# Altium schematic sheet EVK-NORA-W4_SCHEM_0004_Debug_Memory.SchDoc
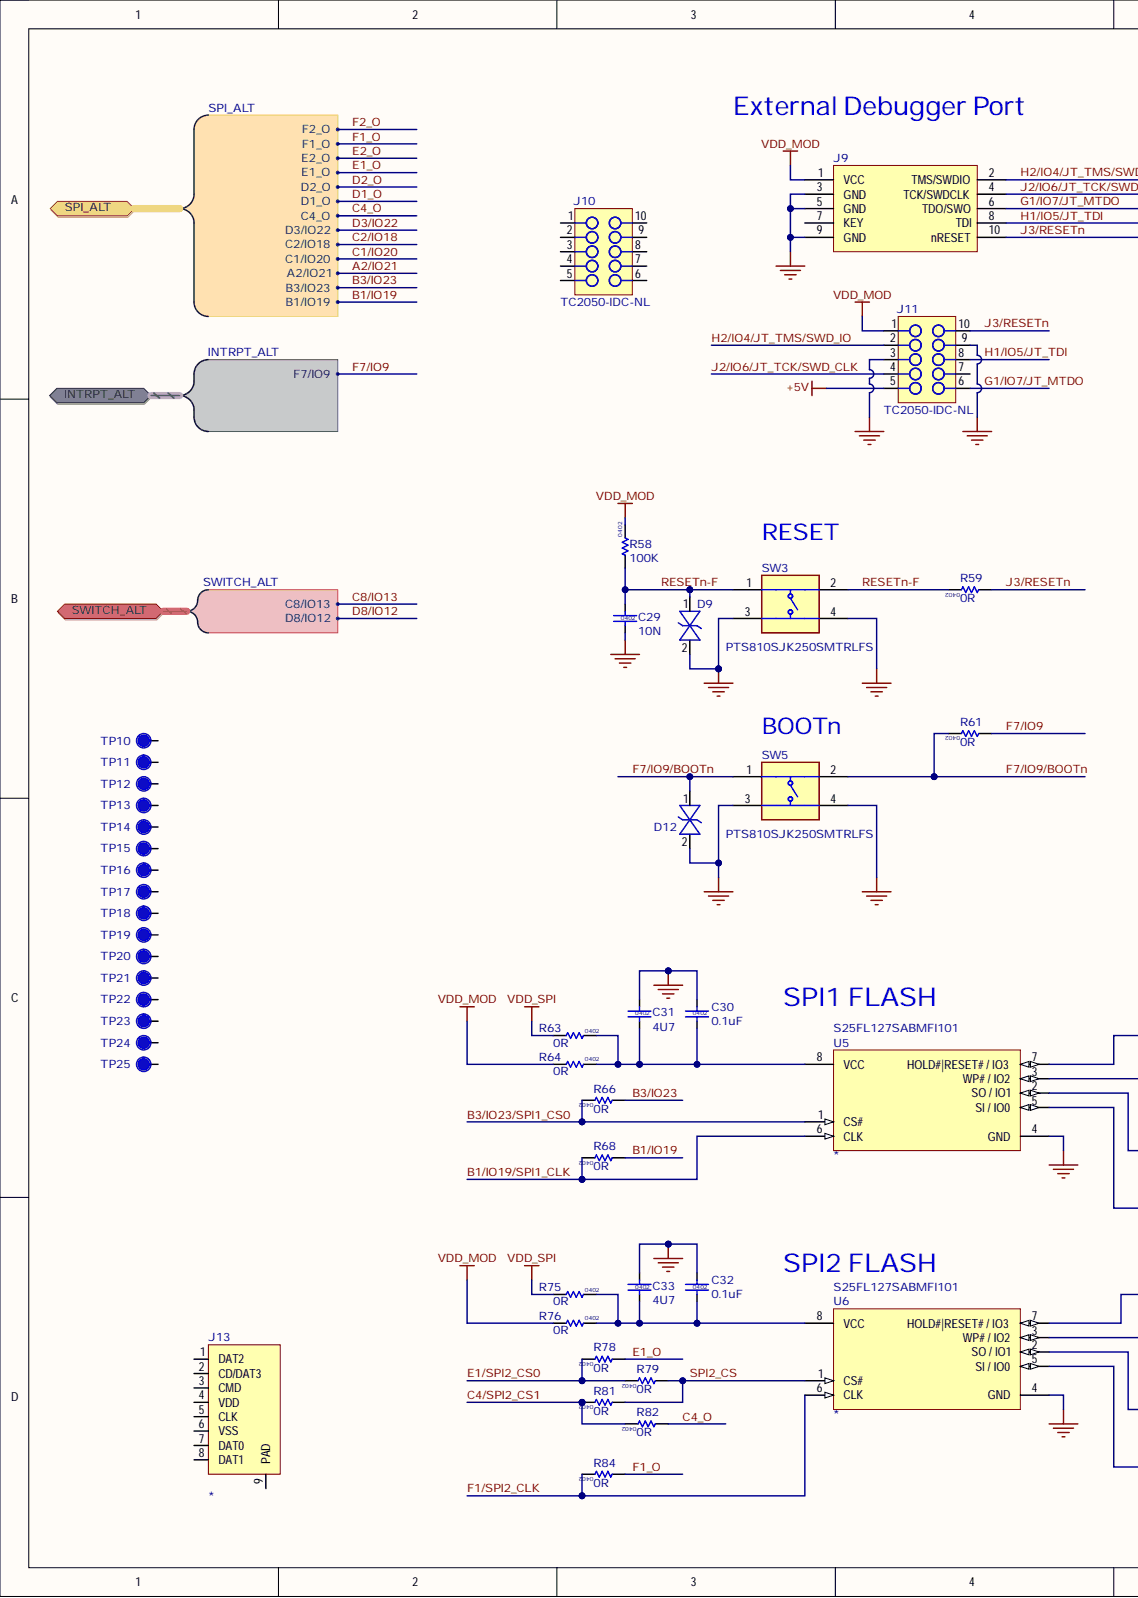
[diagram p0: EVK-NORA-W4_SCHEM_0004_Debug_Memory.SchDoc, left]
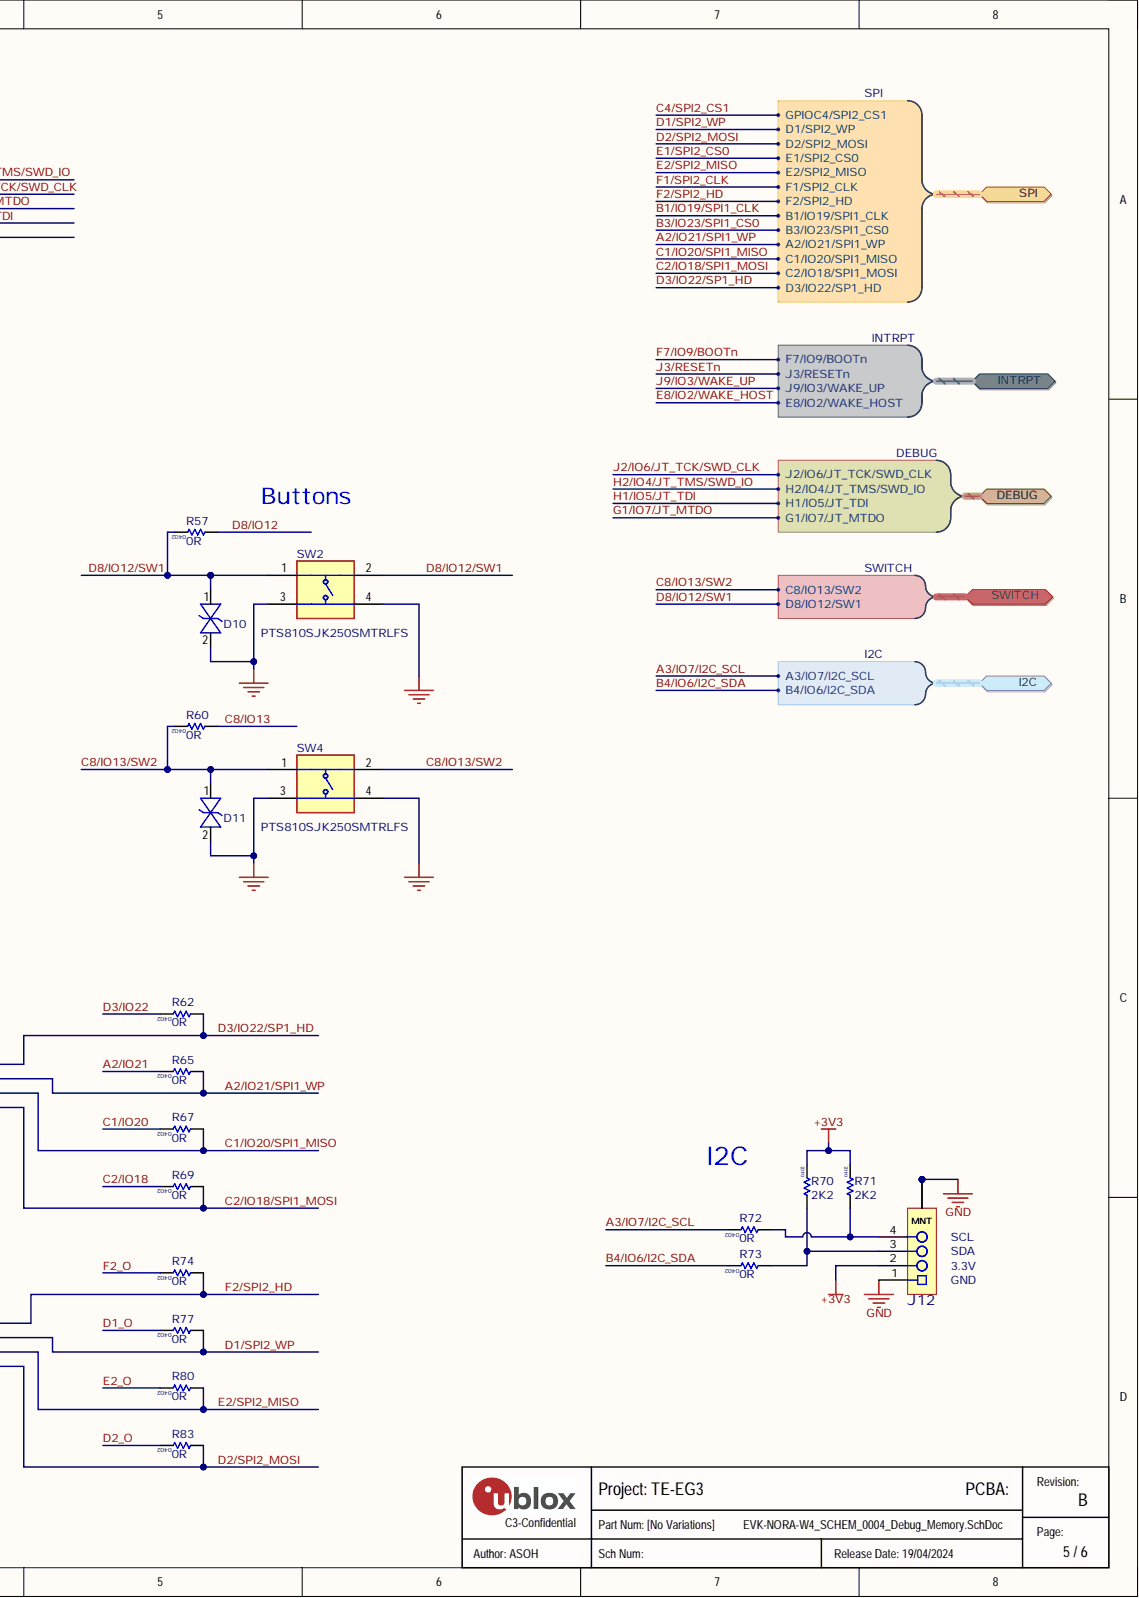
[diagram p1: EVK-NORA-W4_SCHEM_0004_Debug_Memory.SchDoc, right]

## Parts
C29  value 10N  Size: 0402  [Cap_0402_1100Lx600Wx600H_600x600Pad_350G_FLX]  (hidden comment: UBXH01-002302510N)
C30  value 0.1uF  Size: 0402  [Cap 0402 HD]  (hidden comment: 120-000160.1uF)
C31  value 4U7  Size: 0402  [Cap 0402 HD]  (hidden comment: UBXH01-00002434U7)
C32  value 0.1uF  Size: 0402  [Cap 0402 HD]  (hidden comment: 120-000160.1uF)
C33  value 4U7  Size: 0402  [Cap 0402 HD]  (hidden comment: UBXH01-00002434U7)
D9  110-00019  [SOD-923]  (value not drawn)
D10  110-00019  [SOD-923]  (value not drawn)
D11  110-00019  [SOD-923]  (value not drawn)
D12  110-00019  [SOD-923]  (value not drawn)
J9  130-00099  [HDR.050P-10Pin-2x5-SMT_Key]  (value not drawn)
J10  TC2050-IDC-NLDNP  [TC2050-IDC-NL]  (value not drawn)  (no BOM)
J11  TC2050-IDC-NLDNP  [TC2050-IDC-NL]  (value not drawn)  (no BOM)
J12  UBXH04-0000797  [SM04B-SRSS-TB]  (value not drawn)
J13  130-00062  [Micro_SD_SKT_SMT_RA]  (value not drawn)
R57  value 0R  Size: 0402  [RES 0402 HD]  (hidden comment: UBXH18-00030020R)
R58  value 100K  Size: 0402  [RES_0402_1100Lx600Wx400H_500x600Pad_400G_FLX]  (hidden comment: UBXH18-0008097100K)
R59  value 0R  Size: 0402  [RES 0402 HD]  (hidden comment: UBXH18-00030020R)
R60  value 0R  Size: 0402  [RES 0402 HD]  (hidden comment: UBXH18-00030020R)
R61  value 0R  Size: 0402  [RES 0402 HD]  (hidden comment: UBXH18-00030020R)
R62  value 0R  Size: 0402  [RES 0402 HD]  (hidden comment: UBXH18-00030020R)
R63  value 0R  Size: 0402  [RES 0402 HD]  (hidden comment: UBXH18-00030020R)
R64  value 0R  Size: 0402  [RES 0402 HD]  (hidden comment: UBXH18-00030020R)
R65  value 0R  Size: 0402  [RES 0402 HD]  (hidden comment: UBXH18-00030020R)
R66  value 0R  Size: 0402  [RES 0402 HD]  (hidden comment: UBXH18-00030020R)
R67  value 0R  Size: 0402  [RES 0402 HD]  (hidden comment: UBXH18-00030020R)
R68  value 0R  Size: 0402  [RES 0402 HD]  (hidden comment: UBXH18-00030020R)
R69  value 0R  Size: 0402  [RES 0402 HD]  (hidden comment: UBXH18-00030020R)
R70  value 2K2  Size: 0402  [RES_0402_1100Lx600Wx400H_500x600Pad_400G_FLX]  (hidden comment: UBXH18-00080572K2)
R71  value 2K2  Size: 0402  [RES_0402_1100Lx600Wx400H_500x600Pad_400G_FLX]  (hidden comment: UBXH18-00080572K2)
R72  value 0R  Size: 0402  [RES 0402 HD]  (hidden comment: UBXH18-00030020R)
R73  value 0R  Size: 0402  [RES 0402 HD]  (hidden comment: UBXH18-00030020R)
R74  value 0R  Size: 0402  [RES 0402 HD]  (hidden comment: UBXH18-00030020R)
R75  value 0R  Size: 0402  [RES 0402 HD]  (hidden comment: UBXH18-00030020R)
R76  value 0R  Size: 0402  [RES 0402 HD]  (hidden comment: UBXH18-00030020R)
R77  value 0R  Size: 0402  [RES 0402 HD]  (hidden comment: UBXH18-00030020R)
R78  value 0R  Size: 0402  [RES 0402 HD]  (hidden comment: UBXH18-00030020R)
R79  value 0R  Size: 0402  [RES 0402 HD]  (hidden comment: UBXH18-00030020R)
R80  value 0R  Size: 0402  [RES 0402 HD]  (hidden comment: UBXH18-00030020R)
R81  value 0R  Size: 0402  [RES 0402 HD]  (hidden comment: UBXH18-00030020R)
R82  value 0R  Size: 0402  [RES 0402 HD]  (hidden comment: UBXH18-00030020R)
R83  value 0R  Size: 0402  [RES 0402 HD]  (hidden comment: UBXH18-00030020R)
R84  value 0R  Size: 0402  [RES 0402 HD]  (hidden comment: UBXH18-00030020R)
SW2  PTS810SJK250SMTRLFS  [PTS810SJG250SMTRLFS]
SW3  PTS810SJK250SMTRLFS  [PTS810SJG250SMTRLFS]
SW4  PTS810SJK250SMTRLFS  [PTS810SJG250SMTRLFS]
SW5  PTS810SJK250SMTRLFS  [PTS810SJG250SMTRLFS]
TP10  TP  [TP10R]  (no BOM)
TP11  TP  [TP10R]  (no BOM)
TP12  TP  [TP10R]  (no BOM)
TP13  TP  [TP10R]  (no BOM)
TP14  TP  [TP10R]  (no BOM)
TP15  TP  [TP10R]  (no BOM)
TP16  TP  [TP10R]  (no BOM)
TP17  TP  [TP10R]  (no BOM)
TP18  TP  [TP10R]  (no BOM)
TP19  TP  [TP10R]  (no BOM)
TP20  TP  [TP10R]  (no BOM)
TP21  TP  [TP10R]  (no BOM)
TP22  TP  [TP10R]  (no BOM)
TP23  TP  [TP10R]  (no BOM)
TP24  TP  [TP10R]  (no BOM)
TP25  TP  [TP10R]  (no BOM)
U5  Manufacturer Part Number 1: S25FL127SABMFI101  [SOIC-8W]  (hidden comment: 240-00017)
U6  Manufacturer Part Number 1: S25FL127SABMFI101  [SOIC-8W]  (hidden comment: 240-00017)

## Ports
DEBUG, DEBUG.G1/IO7/JT_MTDO, DEBUG.H1/IO5/JT_TDI, DEBUG.H2/IO4/JT_TMS/SWD_IO, DEBUG.J2/IO6/JT_TCK/SWD_CLK, I2C, I2C.A3/IO7/I2C_SCL, I2C.B4/IO6/I2C_SDA, INTRPT, INTRPT.E8/IO2/WAKE_HOST, INTRPT.F7/IO9/BOOTn, INTRPT.J3/RESETn, INTRPT.J9/IO3/WAKE_UP, INTRPT_ALT, INTRPT_ALT.F7/IO9, SPI, SPI.A2/IO21/SPI1_WP, SPI.B1/IO19/SPI1_CLK, SPI.B3/IO23/SPI1_CS0, SPI.C1/IO20/SPI1_MISO, SPI.C2/IO18/SPI1_MOSI, SPI.D1/SPI2_WP, SPI.D2/SPI2_MOSI, SPI.D3/IO22/SP1_HD, SPI.E1/SPI2_CS0, SPI.E2/SPI2_MISO, SPI.F1/SPI2_CLK, SPI.F2/SPI2_HD, SPI.GPIOC4/SPI2_CS1, SPI_ALT, SPI_ALT.A2/IO21, SPI_ALT.B1/IO19, SPI_ALT.B3/IO23, SPI_ALT.C1/IO20, SPI_ALT.C2/IO18, SPI_ALT.C4_O, SPI_ALT.D1_O, SPI_ALT.D2_O, SPI_ALT.D3/IO22, SPI_ALT.E1_O, SPI_ALT.E2_O, SPI_ALT.F1_O, SPI_ALT.F2_O, SWITCH, SWITCH.C8/IO13/SW2, SWITCH.D8/IO12/SW1, SWITCH_ALT, SWITCH_ALT.C8/IO13, SWITCH_ALT.D8/IO12

## Nets
+3V3: J12.2 R70.2 R71.2
+5V: J11.5
GND: C29.2 C30.1 C31.1 C32.1 C33.1 D9.2 D10.2 D11.2 D12.2 J9.3(GND) J9.5(GND) J9.9(GND) J11.3 J12.1 J12.M1 J12.M2 SW2.3 SW2.4 SW3.3 SW3.4 SW4.3 SW4.4 SW5.3 SW5.4 U5.4(GND) U6.4(GND)
VDD_MOD: J9.1(VCC) J11.1 R58.2 R64.1 R76.1
VDD_SPI: R63.1 R75.1
A2/IO21: R65.2 ; port SPI_ALT
A2/IO21/SPI1_WP: R65.1 U5.3(WP#_/_IO2) ; port SPI
A3/IO7/I2C_SCL: R72.2 ; port I2C
B1/IO19: R68.1 ; port SPI_ALT
B1/IO19/SPI1_CLK: R68.2 U5.6(CLK) ; port SPI
B3/IO23: R66.1 ; port SPI_ALT
B3/IO23/SPI1_CS0: R66.2 U5.1(CS#) ; port SPI
B4/IO6/I2C_SDA: R73.2 ; port I2C
C1/IO20: R67.2 ; port SPI_ALT
C1/IO20/SPI1_MISO: R67.1 U5.2(SO_/_IO1) ; port SPI
C2/IO18: R69.2 ; port SPI_ALT
C2/IO18/SPI1_MOSI: R69.1 U5.5(SI_/_IO0) ; port SPI
C4/SPI2_CS1: R81.2 R82.2 ; port SPI
C4_O: R82.1 ; port SPI_ALT
C8/IO13: R60.1 ; port SWITCH_ALT
C8/IO13/SW2: D11.1 R60.2 SW4.1 SW4.2 ; port SWITCH
D1/SPI2_WP: R77.1 U6.3(WP#_/_IO2) ; port SPI
D1_O: R77.2 ; port SPI_ALT
D2/SPI2_MOSI: R83.1 U6.5(SI_/_IO0) ; port SPI
D2_O: R83.2 ; port SPI_ALT
D3/IO22: R62.2 ; port SPI_ALT
D3/IO22/SP1_HD: R62.1 U5.7(HOLD#|RESET#_/_IO3) ; port SPI
D8/IO12: R57.1 ; port SWITCH_ALT
D8/IO12/SW1: D10.1 R57.2 SW2.1 SW2.2 ; port SWITCH
E1/SPI2_CS0: R78.2 R79.2 ; port SPI
E1_O: R78.1 ; port SPI_ALT
E2/SPI2_MISO: R80.1 U6.2(SO_/_IO1) ; port SPI
E2_O: R80.2 ; port SPI_ALT
F1/SPI2_CLK: R84.2 U6.6(CLK) ; port SPI
F1_O: R84.1 ; port SPI_ALT
F2/SPI2_HD: R74.1 U6.7(HOLD#|RESET#_/_IO3) ; port SPI
F2_O: R74.2 ; port SPI_ALT
F7/IO9: R61.1 ; port INTRPT_ALT
F7/IO9/BOOTn: D12.1 R61.2 SW5.1 SW5.2 ; port INTRPT
G1/IO7/JT_MTDO: J9.6(TDO/SWO) J11.6 ; port DEBUG
H1/IO5/JT_TDI: J9.8(TDI) J11.8 ; port DEBUG
H2/IO4/JT_TMS/SWD_IO: J9.2(TMS/SWDIO) J11.2 ; port DEBUG
J2/IO6/JT_TCK/SWD_CLK: J9.4(TCK/SWDCLK) J11.4 ; port DEBUG
J3/RESETn: J9.10(nRESET) J11.10 R59.1 ; port INTRPT
RESETn-F: C29.1 D9.1 R58.1 R59.2 SW3.1 SW3.2
SPI2_CS: R79.1 R81.1 U6.1(CS#)
NetC30_2: C30.2 C31.2 R63.2 R64.2 U5.8(VCC)
NetC32_2: C32.2 C33.2 R75.2 R76.2 U6.8(VCC)
NetJ12_3: J12.3 R70.1 R73.1
NetJ12_4: J12.4 R71.1 R72.1
E8/IO2/WAKE_HOST: ; port INTRPT
J9/IO3/WAKE_UP: ; port INTRPT

Not connected: J9 7(KEY); J10 1 2 3 4 5 6 7 8 9 10; J11 7 9; J13 1(DAT2) 2(CD/DAT3) 3(CMD) 4(VDD) 5(CLK) 6(VSS) 7(DAT0) 8(DAT1) 9(PAD); TP10 1; TP11 1; TP12 1; TP13 1; TP14 1; TP15 1; TP16 1; TP17 1; TP18 1; TP19 1; TP20 1; TP21 1; TP22 1; TP23 1; TP24 1; TP25 1

## Library data of the parts
C29 = UBXH01-0023025: CAP CER X7R 0402 10N 10% 16V; Manufacturer: Murata Manufacturing Company, Ltd.; Manufacturer P/N: GRM155R71C103KA01; Database Table Name: Passive - Capacitor; Editor: roland.rust; Env: ROHS; Mfg P/N Released: Yes; Mfg P/N Split: 100; Mfg P/N Status: NRND; Perferred: PREF; Permitted Use: Volume Products; Released by: anthony.gergis; Component Kind: Standard; Library Name: UBX_Parts_DB_Lib.DbLib; Manufacturer 2: Samsung Electro-Mechanics; Manufacturer P/N 2: CL05B103KO5NNNC; Max Operating Temp: 125°C; Max Voltage: 16V; Mfg P/N Datasheet 2: https://wiki.u-blox.com/bin/view/Generic/Docs/DocumentSummary?index=28567; Mfg P/N Released 2: Yes; Mfg P/N Split 2: 0; Mfg P/N Status 2: Active; Min Operating Temp: -55°C; Part Number: UBXH01-0023025; Part Type: Capacitor; RefDes: C; Release Data: 2021-02-16T13:14:11; Signal Integrity: Cap Semi; Simulation: CAP; Tolerance: 10%; UBX Wiki: https://wiki.u-blox.com/bin/view/Operations/PartDetails?index=5491
C30 C32 = 120-00016: Cap, Ceramic 0.1uF 10V 10% X5R 0402; Manufacturer: Murata Electronics North America; Manufacturer P/N: GRM155R61A104KA01J; Part Name: CAP CER 0.1UF 10V 10% X5R 0402; Component Mounting Type: SMT; Database Table Name: Ceramic Capacitor; Item_Phase: Production; Tolerance: +/- 10%; Use in new designs: Yes; Arena Link: https://app.bom.com/a/GYHPV2L2L43KRMBK?v=spec.summary; Component Kind: Standard; Height: 0.55mm; Manufacturer 2: Yageo; Manufacturer P/N 2: CC0402KRX5R6BB104; Part Number: 120-00016; Part_Type: Ceramic Capacitor; Pins: 2; Revision: 0A; Signal Integrity: Cap Semi; Simulation: CAP; Supplier 1: Digi-Key; Supplier 2: Digi-Key; Supplier Part Number 1: 490-6297-1-ND; Supplier Part Number 2: 311-1336-1-ND; Temperature Coefficient: X5R; Voltage: 10V; Library Name: Arena_Parts_DB_Lib.DbLib
C31 C33 = UBXH01-0000243: CAP CER X5R 0402 4U7 20% 10V; Manufacturer: Murata Manufacturing Company, Ltd.; Manufacturer P/N: GRM155R61A475MEAA; Database Table Name: Passive - Capacitor; Editor: martin.pilik; Env: GREEN; Mfg P/N Released: Yes; Mfg P/N Split: 100; Mfg P/N Status: Active; Perferred: PREF; Permitted Use: Volume Products; Released by: fabrice.bret-dibat; Component Kind: Standard; Library Name: UBX_Parts_DB_Lib.DbLib; Max Operating Temp: 85°C; Max Voltage: 10V; Mfg P/N Datasheet: https://wiki.u-blox.com/bin/view/Generic/Docs/DocumentSummary?index=24302; Min Operating Temp: -40°C; Part Number: UBXH01-0000243; Part Type: Capacitor; RefDes: C; Release Data: 2021-05-10 12:57:47.325652; Signal Integrity: Cap Semi; Simulation: CAP; Tolerance: 20%; UBX Wiki: https://wiki.u-blox.com/bin/view/Operations/PartDetails?index=24303
D9-D12 = 110-00019: ESD9B3.3ST5G; Manufacturer: ON Semiconductor; Part Name: Diode TVS 3.3V SOD-923; Body Size: 0.8mm x 0.6mm; Component Mounting Type: SMT; Database Table Name: Diode; Diode Type: TVS; Item_Phase: Preliminary; Use in new designs: Yes; Voltage: 3.3V; Arena Link: https://app.bom.com/a/4M5DJQ9Q9SR7DV4H?v=spec.summary; Component Kind: Standard; Height: 0.4mm; Manufacturer P/N: ESD9B3.3ST5G; MFG Package: SOD-923; Package Type: SOD; Part Number: 110-00019; Part_Type: Diode; Pins: 2; Supplier 1: Digi-Key; Supplier Part Number 1: ESD9B3.3ST5GOSCT-ND; Library Name: Arena_Parts_DB_Lib.DbLib
J9 = 130-00099: Header 0.05" 10Pin 2Row SMD Black; Manufacturer: Samtec; Manufacturer P/N: FTSH-105-01-F-DV-K; Part Name: CON HDR 0.05" 10Pin 2Row SMD Black; Component Mounting Type: SMT; Database Table Name: Connector; Item_Phase: Pre-Production; Keyed: Yes; Locking: No; Pin Pitch: 0.050"; Rows: 2; Arena Link: https://app.bom.com/a/5N6EKRARATS7TSHL?v=spec.summary; Color: Black; Component Kind: Standard; Connector Type: Header; Height: 6mm; Length: 12.75mm; Part Number: 130-00099; Part_Type: Connector; Pins: 10; Revision: 1; Supplier 1: Digi-Key; Supplier Part Number 1: SAM8796-ND; Use in new designs: Yes; Width: 4.78; Library Name: Arena_Parts_DB_Lib.DbLib
J10 J11 = TC2050-IDC-NL: CON PADS FOR CBL PLUG-OF-NAILS 10-PIN NO LEGS; Manufacturer: TAG Connect; Added By: Paul B; Database Table Name: Testpoints & Pads; Added Date: 12/3/2015; DNP: DNP; Height: 0; Manufacturer P/N: TC2050-IDC-NL; Part Number: TC2050-IDC-NL; Size: 6.1mm x 9.9mm; Library Name: LEGACY_Rigado_AltiumLib.DbLib
J12 = UBXH04-0000797: CONN JST SH SERIES SMT 4 POS MALE 1MM RA 50V 1A; Manufacturer 1: JST Sales America Inc.; Manufacturer Part Number 1: SM04B-SRSS-TB(LF)(SN); Part Name: CONN HEADER SMD R/A 4POS 1MM; Database Table Name: Electromechanical - Connector; Editor: eric.moog; Env: ROHS; Item Phase: In Production; Mfg P/N Released: No; Mfg P/N Split: 100; Mfg P/N Status: Active; Perferred: PREF; Permitted Use: Support Products; UBX Code: None; Use in new designs: Yes; Value: SM04B-SRSS-TB; Component Kind: Standard; Diameter: None; Height: 2.9mm; Library Name: UBX_Parts_DB_Lib.DbLib; Manufacturer: JST; Manufacturer 2: None; Manufacturer P/N: SM04B-SRSS-TB; Manufacturer Part Number 2: None; Max Current: 1A; Max Operating Temp: 85°C; Max Voltage: 50V; Mfg P/N Datasheet: https://wiki.u-blox.com/bin/view/Generic/Docs/DocumentSummary?index=4533; Min Operating Temp: -30°C; Part Number: UBXH04-0000797; Part Type: Connector; Pins: 4; RefDes: J; Revision: 0A; Supplier 1: None; Supplier 2: None; Supplier Part Number 1: None; Supplier Part Number 2: None; UBX Wiki: https://wiki.u-blox.com/bin/view/Operations/PartDetails?index=38779; Voltage: None
J13 = 130-00062: Micro SD Card Socket, Right Angle, with 4 Ground Pads, SMT; Manufacturer 1: Molex Inc; Manufacturer Part Number 1: 0472192001; Part Name: CON Micro SD 1.1mm 8Pin 1Row SMT RA; Database Table Name: Connector; Item Phase: In Production; UBX Code: None; Use in new designs: Yes; Component Kind: Standard; Diameter: None; Height: 1.9mm; Manufacturer 2: None; Manufacturer Part Number 2: None; Max Operating Temp: None; Min Operating Temp: None; Part Number: 130-00062; Pins: 8; Revision: 0A; Supplier 1: Digi-Key; Supplier 2: None; Supplier Part Number 1: WM6698CT-ND; Supplier Part Number 2: None; Voltage: None; Library Name: Arena_Parts_DB_Lib.DbLib
R57 R59-R62 R65-R69 R72-R74 R77-R84 = UBXH18-0003002: RES THICK FILM CHIP 0402 0R 0 100mW; Manufacturer: KOA; Manufacturer P/N: RK73Z1ETTP; Database Table Name: Passive - Resistor; Editor: charlotte.biber; Env: ROHS; Mfg P/N Released: Yes; Mfg P/N Split: 100; Mfg P/N Status: NRND; Perferred: PREF; Permitted Use: Volume Products; Released by: peter.mueller; Tolerance: 0; Component Kind: Standard; Library Name: UBX_Parts_DB_Lib.DbLib; Manufacturer 2: YAGEO; Manufacturer P/N 2: 2322_705_91001_L; Max Power: 100mW; Mfg P/N Released 2: Yes; Mfg P/N Split 2: 0; Mfg P/N Status 2: NRND; Part Number: UBXH18-0003002; Part Type: Resistor; RefDes: R; Release Data: 2012-06-05 09:49:55.978616; Signal Integrity: Res; Simulation: RESISTOR; UBX Wiki: https://wiki.u-blox.com/bin/view/Operations/PartDetails?index=6611
R58 = UBXH18-0008097: RES THICK FILM CHIP 0402 100K 5% 100mW; Manufacturer: YAGEO; Manufacturer P/N: 2322_705_70104_L; Database Table Name: Passive - Resistor; Editor: roland.rust; Env: ROHS; Mfg P/N Released: Yes; Mfg P/N Split: 100; Mfg P/N Status: Obsolete; Perferred: PREF; Permitted Use: Volume Products; Component Kind: Standard; Library Name: UBX_Parts_DB_Lib.DbLib; Manufacturer 2: KOA; Manufacturer P/N 2: RK73B1ETTD104J; Max Power: 100mW; Mfg P/N Released 2: Yes; Mfg P/N Split 2: 0; Mfg P/N Status 2: NRND; Part Number: UBXH18-0008097; Part Type: Resistor; RefDes: R; Release Data: 2005-07-22T13:59:44; Signal Integrity: Res; Simulation: RESISTOR; Tolerance: 5%; UBX Wiki: https://wiki.u-blox.com/bin/view/Operations/PartDetails?index=7020; Released by: roland.rust
R63 R64 R75 R76 = UBXH18-0003002: RES THICK FILM CHIP 0402 0R 0 100mW; Manufacturer: KOA; Manufacturer P/N: RK73Z1ETTD; Database Table Name: Passive - Resistor; Editor: charlotte.biber; Env: ROHS; Mfg P/N Released: Yes; Mfg P/N Split: 100; Mfg P/N Status: NRND; Perferred: PREF; Permitted Use: Volume Products; Released by: peter.mueller; Tolerance: 0; Component Kind: Standard; Library Name: UBX_Parts_DB_Lib.DbLib; Manufacturer 2: YAGEO; Manufacturer P/N 2: 2322_705_91001_L; Max Power: 100mW; Mfg P/N Released 2: Yes; Mfg P/N Split 2: 0; Mfg P/N Status 2: NRND; Part Number: UBXH18-0003002; Part Type: Resistor; RefDes: R; Release Data: 2012-06-05 09:49:55.978616; Signal Integrity: Res; Simulation: RESISTOR; UBX Wiki: https://wiki.u-blox.com/bin/view/Operations/PartDetails?index=6611
R70 R71 = UBXH18-0008057: RES THICK FILM CHIP 0402 2K2 5% 100mW; Manufacturer: YAGEO; Manufacturer P/N: 2322_705_87222_L; Database Table Name: Passive - Resistor; Editor: roland.rust; Env: ROHS; Mfg P/N Released: Yes; Mfg P/N Split: 100; Mfg P/N Status: Obsolete; Perferred: PREF; Permitted Use: Volume Products; Component Kind: Standard; Library Name: UBX_Parts_DB_Lib.DbLib; Manufacturer 2: YAGEO; Manufacturer P/N 2: RC0402JR-072K2L; Max Power: 100mW; Mfg P/N Datasheet 2: https://wiki.u-blox.com/bin/view/Generic/Docs/DocumentSummary?index=8163; Mfg P/N Released 2: Yes; Mfg P/N Split 2: 0; Mfg P/N Status 2: Active; Part Number: UBXH18-0008057; Part Type: Resistor; RefDes: R; Release Data: 2007-02-09T10:46:57; Signal Integrity: Res; Simulation: RESISTOR; Tolerance: 5%; UBX Wiki: https://wiki.u-blox.com/bin/view/Operations/PartDetails?index=6956; Released by: roland.rust
SW2-SW5 = PTS810SJK250SMTRLFS: Switch; Manufacturer_Name: C & K COMPONENTS; Component Kind: Standard; Datasheet Link: https://www.ckswitches.com/media/1476/pts810.pdf; Library Name: SamacSys.SchLib; Manufacturer_Part_Number: PTS810SJK250SMTRLFS; Mouser Part Number: 611-PTS810SJK250SMTR; Mouser Price/Stock: https://www.mouser.co.uk/ProductDetail/CK/PTS810SJK250SMTRLFS?qs=UxeAxwACbqlfHzoZSrW3%2Fw%3D%3D
TP10-TP25 = TP10R: Testpoint, Round 1.0mm Dia; Added By: Jeremy D; Database Table Name: Testpoints & Pads; Added Date: 2/1/2015; Checked Date: 2/5/2015; DNP: DNP; Height: 0; Part Number: TP10R; Size: 1.0mm; Checked By: Paul B; Library Name: LEGACY_Rigado_AltiumLib.DbLib
U5 U6 = 240-00017: IC, Flash 128MBIT, 108MHz, 8SOIC; Manufacturer 1: Cypress Semiconductor Corp; Part Name: IC Flash 128MBIT 108MHz 8SOIC; Body Size: 5.28mm x 5.28mm; Database Table Name: Memory; Interface: SPI; Item Phase: Unreleased; Memory Type: Flash - NOR; MFG Package: 8-SOIC; SMT Pitch: 1.27mm; Thermal Pad: No; UBX Code: None; Use in new designs: Yes; Voltage: 3.6V; Component Kind: Standard; Height: 2.16mm; Manufacturer 2: None; Manufacturer Part Number 2: None; Max Operating Temp: 85; Memory Size: 128Mbit; Memory Speed: 108MHz; Min Operating Temp: -40; Part Number: 240-00017; Pins: 8; Revision: None; Supplier 1: Digi-Key; Supplier 2: None; Supplier Part Number 1: 1274-1045-ND; Supplier Part Number 2: None; Library Name: Arena_Parts_DB_Lib.DbLib
